# Altium schematic sheet 03_ST-Link.SchDoc
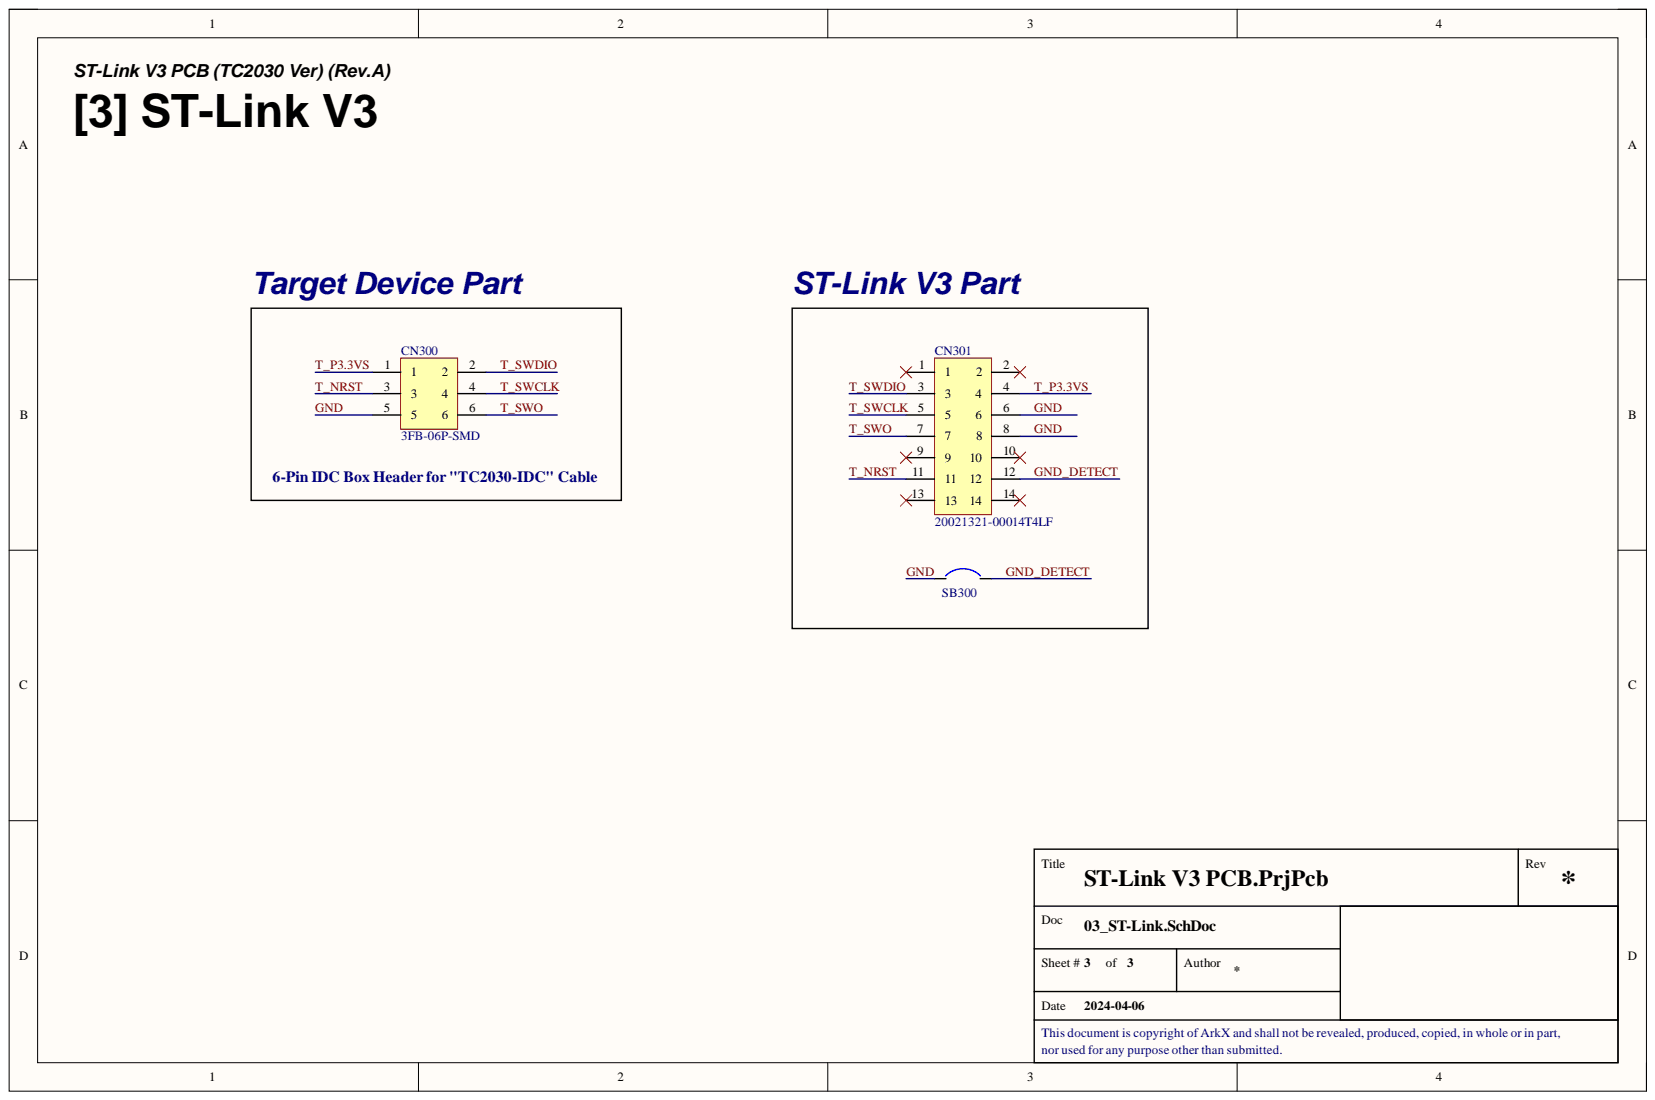
[diagram p0: 03_ST-Link.SchDoc]

## Parts
CN300  3FB-06P-SMD  [CON_BOX_HEADER_DUAL_2x3PIN(2.54MM)]
CN301  20021321-00014T4LF  [CON_RCPT_DUAL_2x7PIN(1.27MM)]
SB300  SB  [SB (Solder-Bridge)]

## Nets
GND: CN300.5 CN301.6 CN301.8 SB300.1
GND_DETECT: CN301.12 SB300.2
T_NRST: CN300.3 CN301.11
T_P3.3VS: CN300.1 CN301.4
T_SWCLK: CN300.4 CN301.5
T_SWDIO: CN300.2 CN301.3
T_SWO: CN300.6 CN301.7

Not connected: CN301 1 2 9 10 13 14

## Library data of the parts
CN300 = CON_BOX_HEADER_DUAL_2x3PIN(2.54MM): BOX HEADER SMD / 2.54mm pitch / with IDC Connector; Component Kind: Standard; Library Name: Arkx.IntLib
CN301 = CON_RCPT_DUAL_2x7PIN(1.27MM): 14 Position Receptacle Connector 0.050" (1.27mm) Surface Mount Gold; Component Kind: Standard; JLCPCB Part Type: Extended Part; LCSC Part #: C5412490; Library Name: Arkx.IntLib
SB300 = SB_Solder-Bridge: Component Kind: Standard; Description: SB_Solder-Bridge; Library Name: Arkx.IntLib
